# Altium schematic sheet Carte_IPAv.SchDoc(Carte_IPAv)
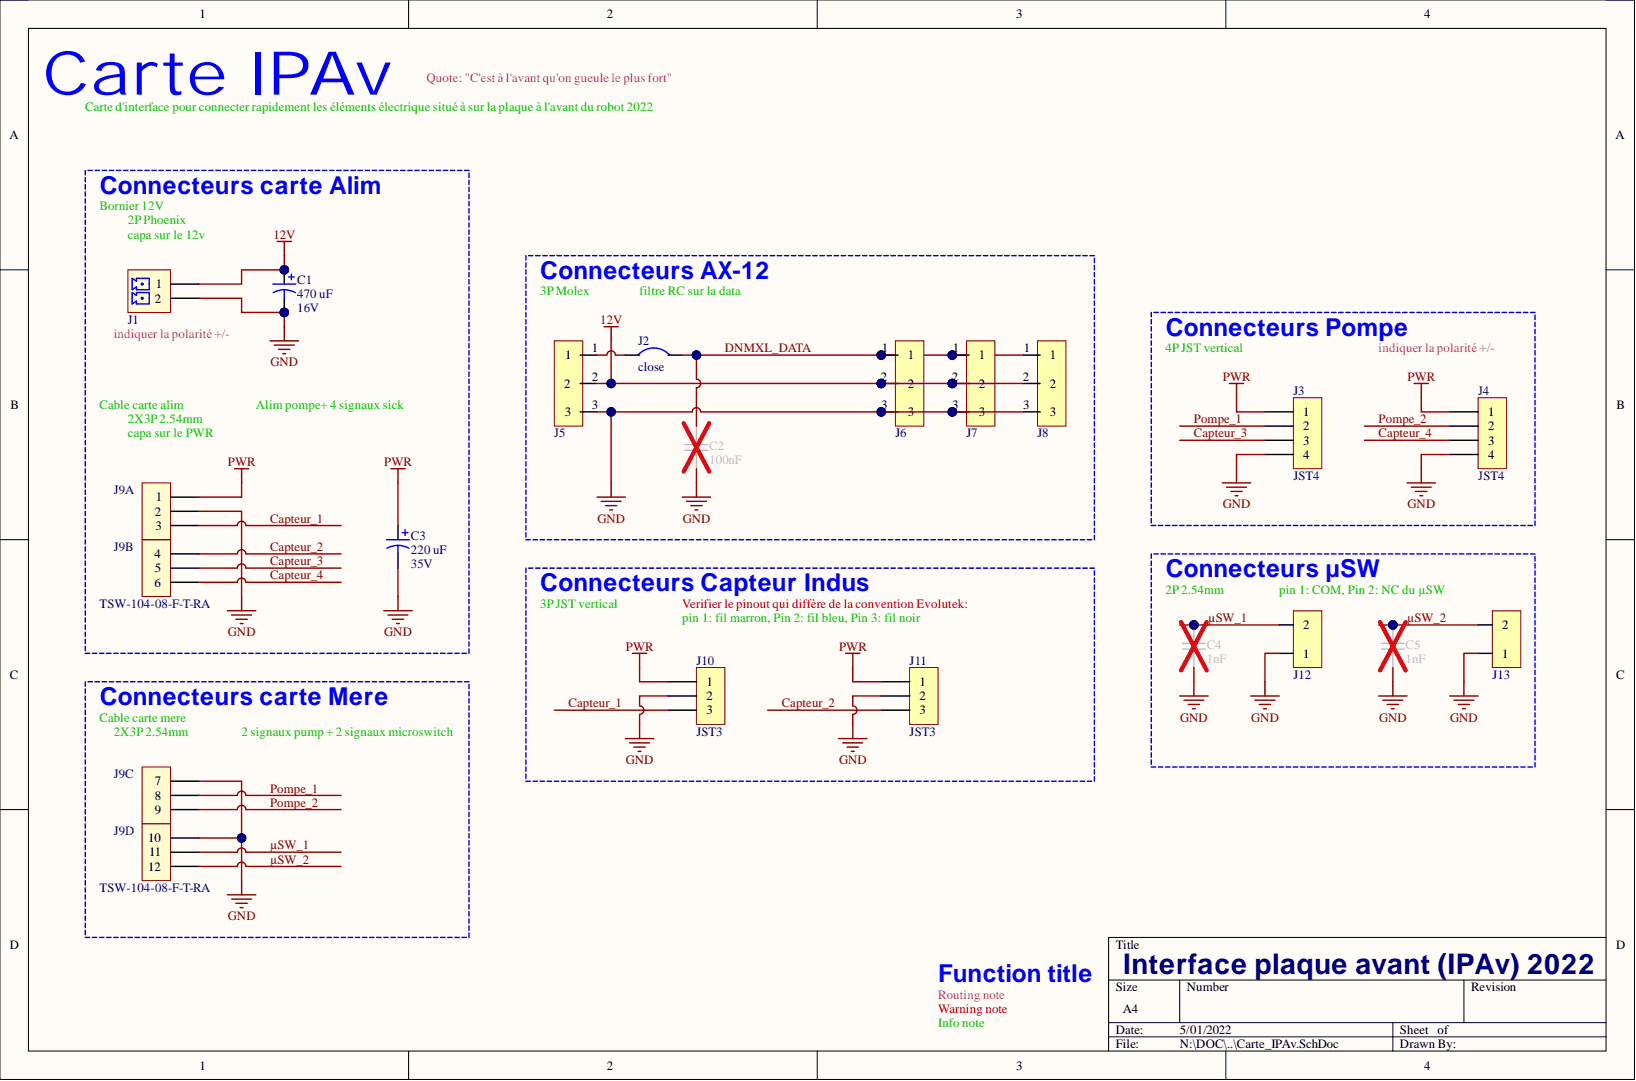
[diagram p0: Carte_IPAv.SchDoc]

## Parts
C1  470 uF  RatedVoltage: 16V  [WCAP-ATG8_8x11.5x3.5x0.5]
C2  100nF  [WCAP-CSGP_0603, 1.6x0.8x0.8]
C3  220 uF  RatedVoltage: 35V  [WCAP-ATG8_8x11.5x3.5x0.5]
C4  1nF  [WCAP-CSGP_0402, 1x0.5x0.5]
C5  1nF  [WCAP-CSGP_0402, 1x0.5x0.5]
J1  value 2  [691321100002]  (hidden comment: 691321100002)
J2  close  [CAVALIER_0603_C]
J3  JST4  [JST4]
J4  JST4  [JST4]
J5  22-03-5035  [20-03-5035]  (value not drawn)
J6  22-03-5035  [20-03-5035]  (value not drawn)
J7  22-03-5035  [20-03-5035]  (value not drawn)
J8  22-03-5035  [20-03-5035]  (value not drawn)
J9  TSW-104-08-F-T-RA  [TSW-104-08-F-T-RA]  (parts A,B,C,D)
J10  JST3  [JST3]
J11  JST3  [JST3]
J12  22-03-2021  [22-03-2021]  (value not drawn)
J13  22-03-2021  [22-03-2021]  (value not drawn)

## Nets
12V: C1.1 J1.1 J5.2 J6.2 J7.2 J8.2
GND: C1.2 C2.2 C3.2 C4.2 C5.2 J1.2 J3.4 J4.4 J5.3 J6.3 J7.3 J8.3 J9.2 J9.7 J9.10 J10.2 J11.2 J12.1 J13.1
PWR: C3.1 J3.1 J4.1 J9.1 J10.1 J11.1
Capteur_1: J9.3 J10.3
Capteur_2: J9.4 J11.3
Capteur_3: J3.3 J9.5
Capteur_4: J4.3 J9.6
DNMXL_DATA: C2.1 J2.2 J6.1 J7.1 J8.1
Pompe_1: J3.2 J9.8
Pompe_2: J4.2 J9.9
µSW_1: C4.1 J9.11 J12.2
µSW_2: C5.1 J9.12 J13.2
NetJ2_1: J2.1 J5.1

## Library data of the parts
C1 = WCAP-ATG8, 860010374012: CAP Alu 470 UF 20% 16 V; Manufacturer: Wurth Elektronik; Component Kind: Standard; ComponentLink1Description: Datasheet; ComponentLink1URL: http://katalog.we-online.de/pbs/datasheet/860010374012.pdf; Manufacturer Part Number: 860010374012; Size: 8mmx11.5mm; Value: 470 uF; Library Name: Condensateur.IntLib
C2 = WCAP-CSGP, 885012206095: CAP CER 100nF 50V X7R 0603; Manufacturer: Wurth Elektronik; CeramicType: X7R; Component Kind: Standard; ComponentLink1Description: Datasheet; ComponentLink1URL: http://katalog.we-online.com/pbs/datasheet/885012206095.pdf; Manufacturer Part Number: 885012206095; RatedVoltage: 50V; Size: 0603; Supplier 1: Farnell; Supplier 2: JLCPCB; Supplier Footprint 2: 0603; Supplier Part Number 1: 2495187; Supplier Part Number 2: C14663; Value: 100nF; Library Name: Condensateur.IntLib
C3 = WCAP-ATG8, 860010574011: CAP Alu 220 UF 20% 35 V; Manufacturer: Wurth Elektronik; Component Kind: Standard; ComponentLink1Description: Datasheet; ComponentLink1URL: http://katalog.we-online.de/pbs/datasheet/860010574011.pdf; Manufacturer Part Number: 860010574011; Size: 8mmx11.5mm; Value: 220 uF; Library Name: Condensateur.IntLib
C4 C5 = WCAP-CSGP, 885012205061: CAP CER 1nF 50V X7R 0402; Manufacturer: Wurth Elektronik; CeramicType: X7R; Component Kind: Standard; ComponentLink1Description: Datasheet; ComponentLink1URL: http://katalog.we-online.com/pbs/datasheet/885012205061.pdf; Manufacturer Part Number: 885012205061; RatedVoltage: 50V; Size: 0402; Supplier 2: JLCPCB; Supplier Footprint 2: 0402; Supplier Part Number 2: C1523; Value: 1nF; Library Name: Condensateur.IntLib
J1 = 691321100002: WR-TBL 2p Series 3211 - 3.50 mm Vertical PCB Header; Manufacturer: Wurth Electronics Inc.; Component Kind: Standard; ComponentLink1Description: Datasheet; ComponentLink1URL: https://katalog.we-online.de/em/datasheet/6913211000xx.pdf; Manufacturer Part Number: 691321100002; Supplier 1: Farnell; Supplier Part Number 1: 1841308; Library Name: Connecteurs.IntLib
J2 = CAVALIER_0603_C: Cavalier soudure "fermé" + empreinte dipole 0603; Component Kind: Net Tie; Library Name: Divers.IntLib
J5-J8 = 20-03-5035: CONN HEADER VERT 3POS 2.5MM; Manufacturer: MOLEX; Component Kind: Standard; ComponentLink1Description: Datasheet; ComponentLink1URL: http://www.farnell.com/datasheets/1801024.pdf; ComponentLink2Description: Drawings; ComponentLink2URL: http://www.farnell.com/cad/495071.pdf  http://www.farnell.com/cad/1533907.pdf  http://www.farnell.com/cad/1533907.pdf; Manufacturer Part Number: 22-03-5035; Supplier 1: Farnell; Supplier Part Number 1: 9979620; Library Name: Connecteurs.IntLib
J9 = TSW-104-08-F-T-RA: CONN HEADER R/A 3L x 4POS 2.54MM; Manufacturer: SAMTEC; Component Kind: Standard; ComponentLink1Description: Datasheet; ComponentLink1URL: http://cloud.samtec.com/catalog_english/TSW_TH.PDF; Manufacturer Part Number: TSW-104-08-F-T-RA; Supplier 1: Farnell; Supplier Part Number 1: 2053041; Library Name: Connecteurs.IntLib
J12 J13 = 22-03-2021: CONN HEADER VERT 2POS 2.54MM; Manufacturer: MOLEX; Component Kind: Standard; ComponentLink1Description: Datasheet; ComponentLink1URL: http://www.farnell.com/datasheets/1791308.pdf; ComponentLink2Description: Drawings; ComponentLink2URL: http://www.farnell.com/cad/495071.pdf  http://www.farnell.com/cad/1533907.pdf  http://www.farnell.com/cad/1533907.pdf; Library Name: Molex.SchLib; Manufacturer Part Number: 22-03-2021; Supplier 1: Farnell; Supplier Part Number 1: 9731075
J3 J4 = JST4: Component Kind: Standard; Library Name: JST.SchLib
J10 J11 = JST3: Component Kind: Standard; Library Name: JST.SchLib
